# Altium schematic sheet DcDc5V.SchDoc
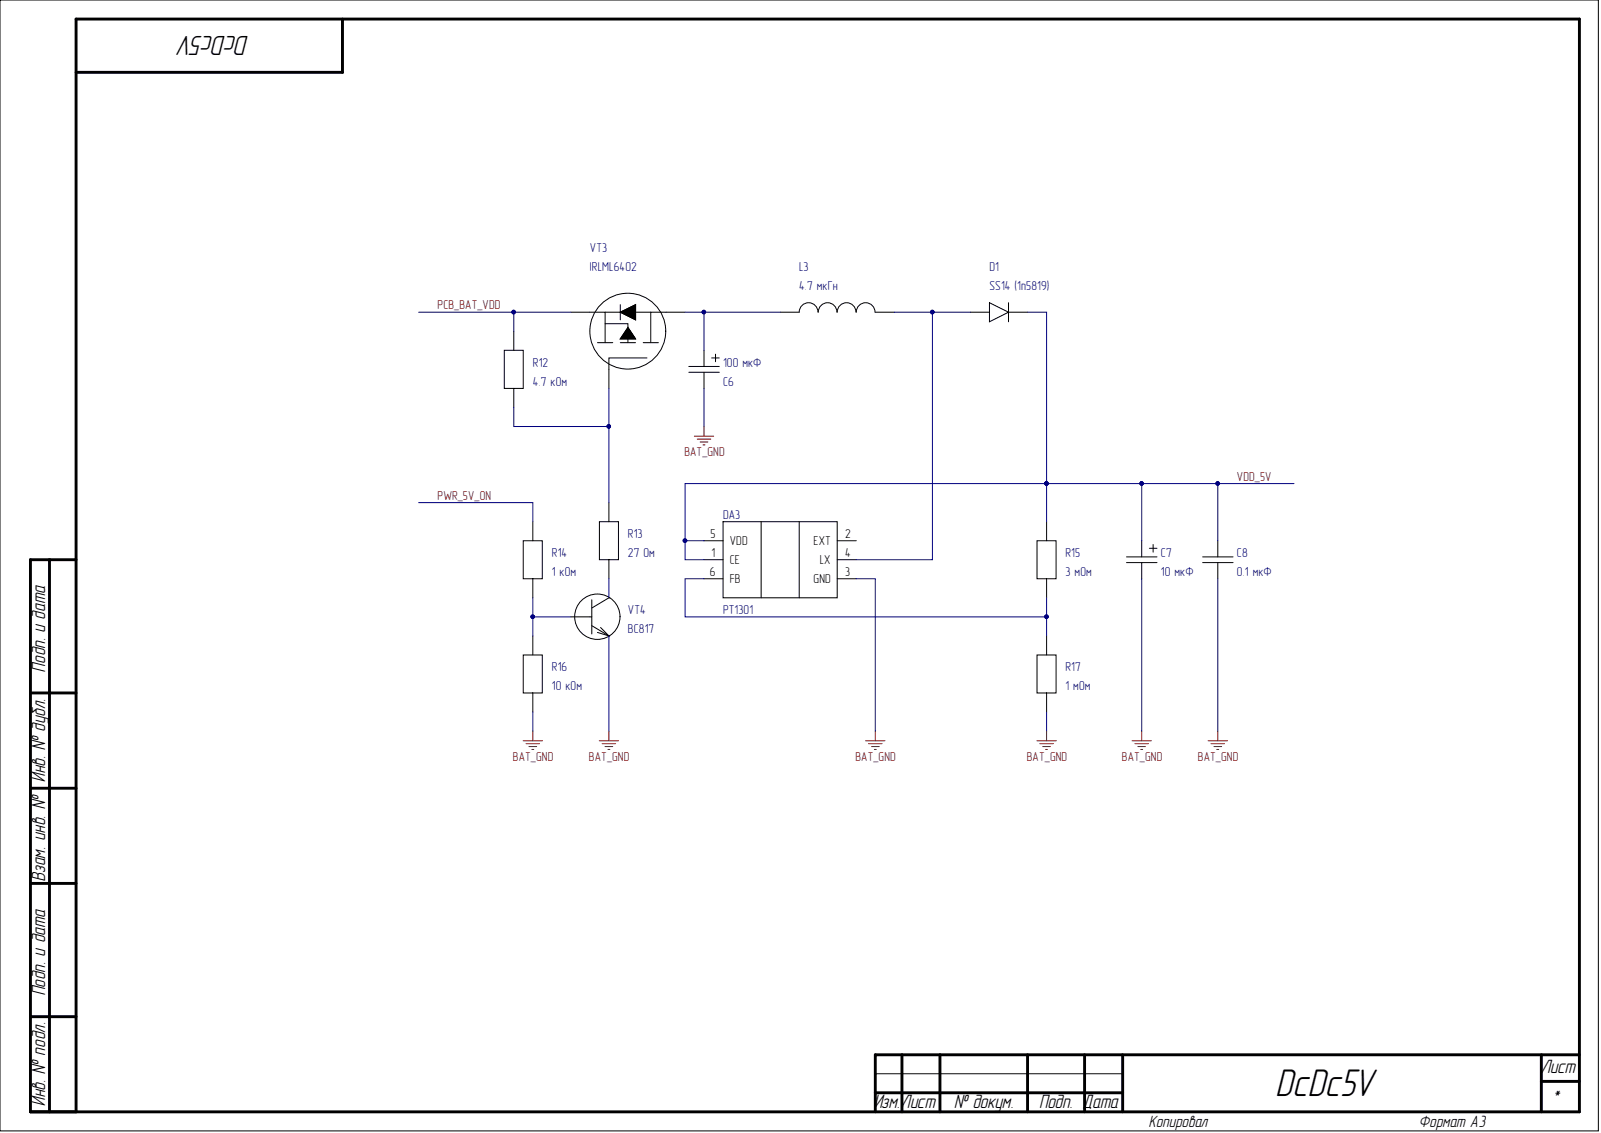
[diagram p0: DcDc5V.SchDoc]

## Parts
C6  100 мкФ  [C TAN_C]
C7  10 мкФ  [C TAN_A]
C8  0.1 мкФ  [C 0805]
D1  SS14 (1n5819)  [SMA/DO-214AC]
DA3  PT1301  [SOT-23-6]
L3  4.7 мкГн  [CD43]
R12  4.7 кОм  [RES 0402]
R13  27 Ом  [RES 0402]
R14  1 кОм  [RES 0402]
R15  3 мОм  [RES 0402]
R16  10 кОм  [RES 0402]
R17  1 мОм  [RES 0402]
VT3  IRLML6402  [SOT-23]
VT4  BC817  [SOT-23]

## Nets
BAT_GND: C6.2 C7.2 C8.2 DA3.3(GND) R16.1 R17.1 VT4.2
PCB_BAT_VDD: R12.2 VT3.2
PWR_5V_ON: R14.2
VDD_5V: C7.1 C8.1 D1.C DA3.1(CE) DA3.5(VDD) R15.2
NetC6_1: C6.1 L3.1 VT3.3
NetD1_A: D1.A DA3.4(LX) L3.2
NetDA3_6: DA3.6(FB) R15.1 R17.2
NetR12_1: R12.1 R13.2 VT3.1
NetR13_1: R13.1 VT4.3
NetR14_1: R14.1 R16.2 VT4.1

Not connected: DA3 2(EXT)

## Library data of the parts
C6 = CAP TAN_C: SMD Tantalium Capacitor C; Type: Конденсатор танталовый; Component Kind: Standard; Library Name: my_lib.SchLib; Size: C
C7 = CAP TAN_A: SMD Tantalium Capacitor A; Rated voltage: 10 В; Type: Конденсатор танталовый; Component Kind: Standard; Library Name: my_lib.SchLib; Size: A
C8 = CAP 0805: SMD Capacitor 0805; Manufacturer: /Укажите компанию-производителя/; TC: /Укажите тип диэлектрика/; Temperature coefficient: /Формат: ±? ppm/°C/; Tolerance: /Укажите допуск в процентах/; Type: Конденсатор керамический; Component Kind: Standard; Library Name: my_lib.SchLib; Size: 0805; Temperature range: /Формат: -? ... ? °C/
D1 = D SS14 (1n5819): Component Kind: Standard; Library Name: my_lib.SchLib
DA3 = PT1301: DC-DC step-up (повышающий) PT1301 в корпусе SOT23-6.; Component Kind: Standard; Library Name: my_lib.SchLib
L3 = L 4.7 uH: Катушка индуктивности 4.7 мкГн корпус CD43 (индуктивность 4.7 uH).; Component Kind: Standard; Library Name: my_lib.SchLib
R12-R17 = RES 0402: Component Kind: Standard; Library Name: my_lib.SchLib
VT4 = BC817: NPN general-purpose transistor; Manufacturer: NXP; Base-emitter on voltage: 1,2 В; Collector-base сapacitance: 3 пФ; Collector-emitter saturation voltage: 0,7 В; Complement: BC807; fT: 100 МГц; hFE: 160 ... 600; Max collector-base voltage: 50 В; Max collector-emitter voltage: 45 В; Max collector current: 0,5 А; Max collector peak current: 1 А; Max emitter-base voltage: 5 В; Power dissitipation: 0,25 Вт; Specitication: ЭКБ ИП; Structure: n-p-n; Type: Транзистор биполярный; Component Kind: Standard; Library Name: my_lib.SchLib; Temperature range: -65 ... 150 °C
VT3 = IRLML6402: Component Kind: Standard; Library Name: my_lib.SchLib
